# Altium schematic sheet Display and Control.SchDoc("Display and Control")
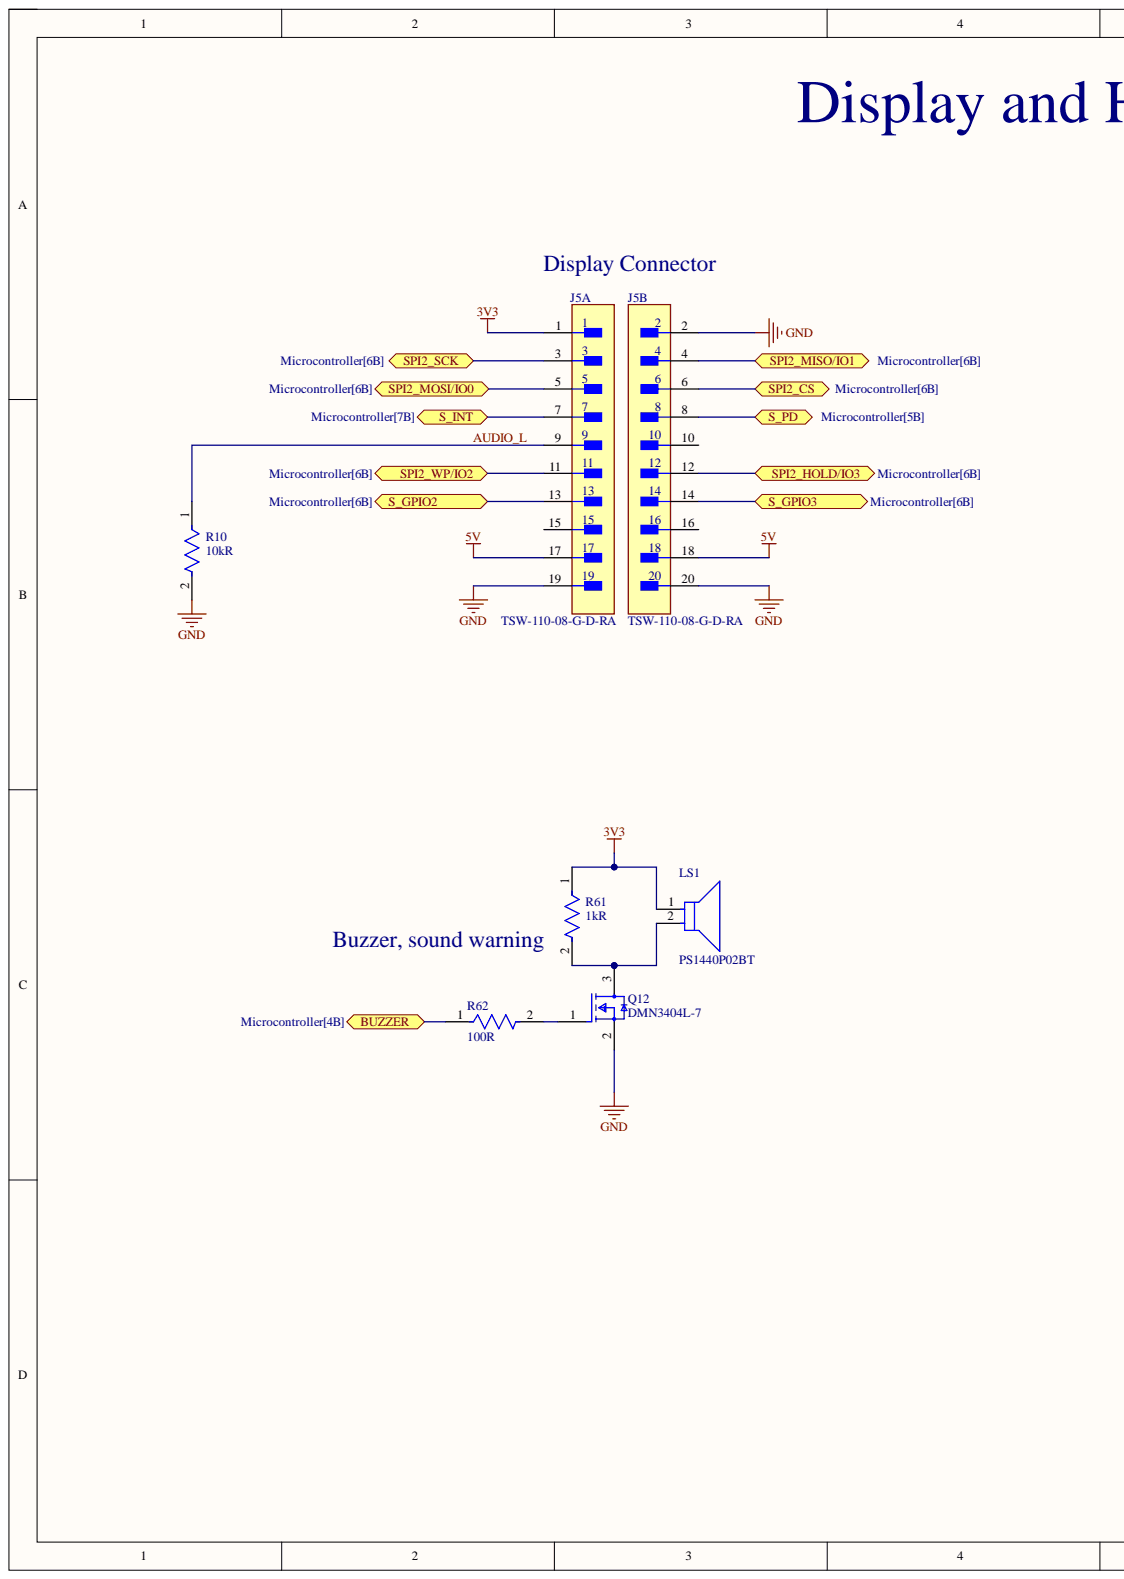
[diagram p0: Display and Control.SchDoc, left]
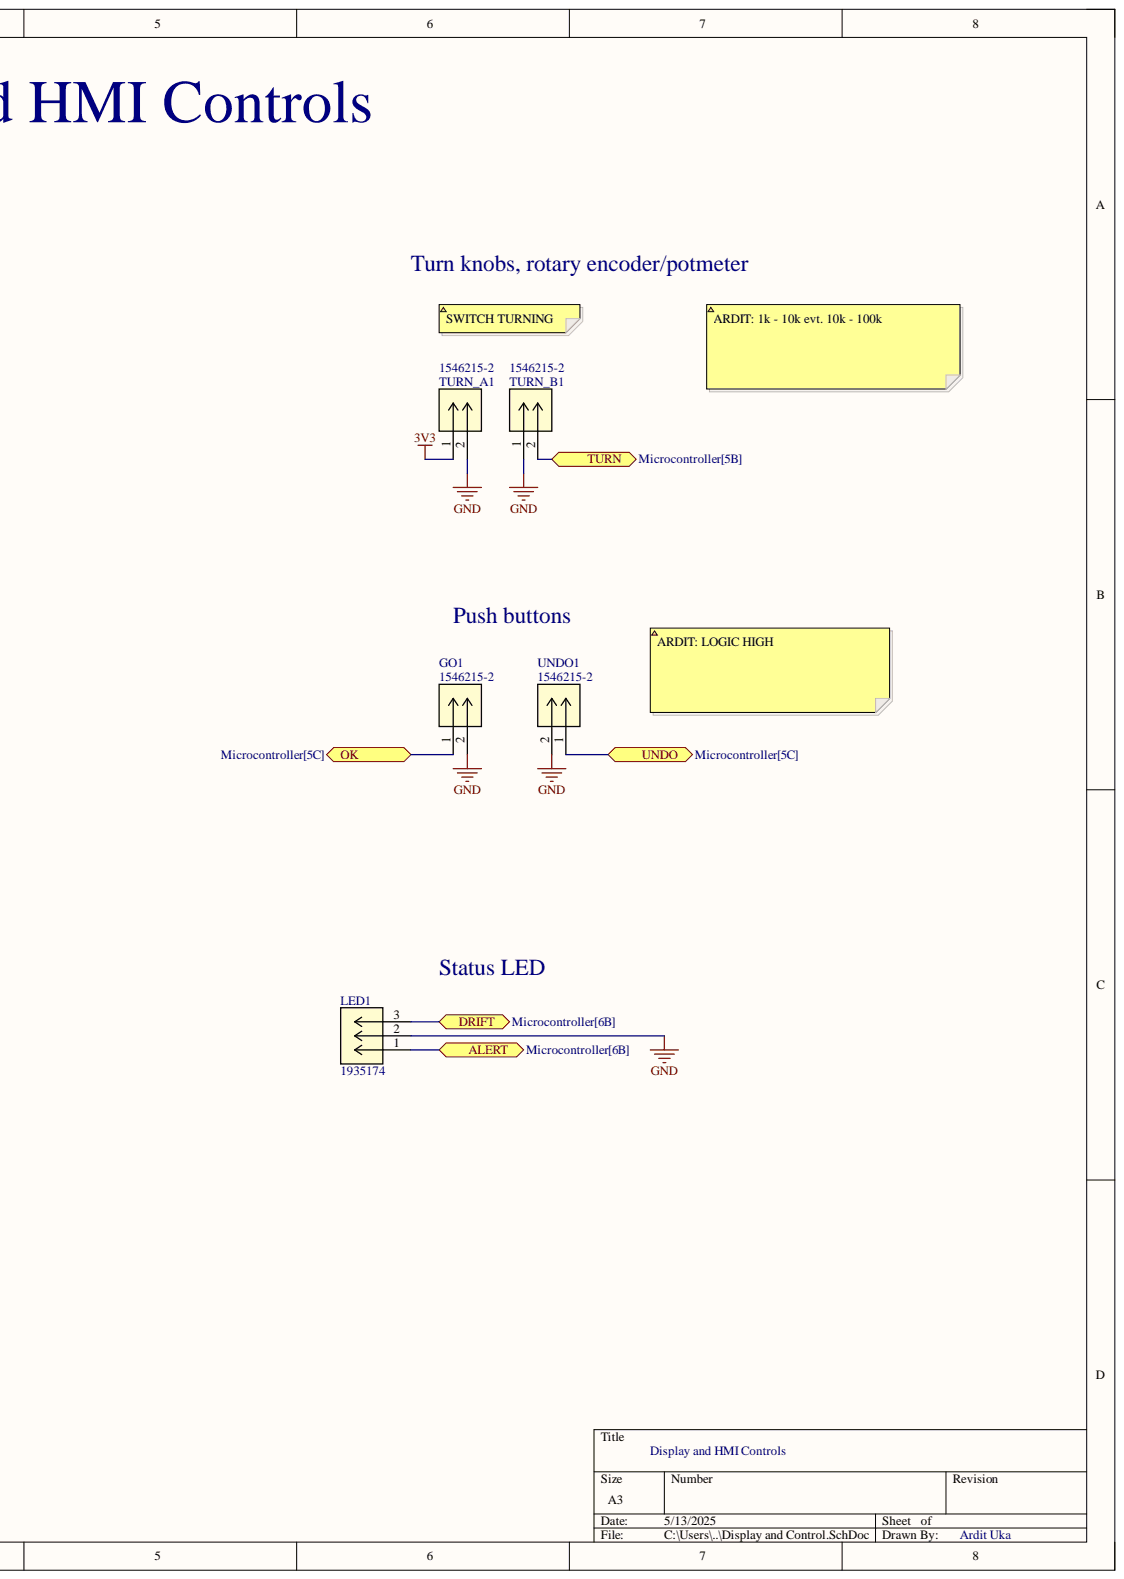
[diagram p1: Display and Control.SchDoc, right]

## Parts
GO1  1546215-2  [CONN_1546215-2_TEC]
J5  TSW-110-08-G-D-RA  [FP-TSW-110-08-G-D-RA-MFG]  (parts A,B)
LED1  1935174  [CONN_1935174_PXC]
LS1  PS1440P02BT  [FP-PS1440P02BT-MFG]
Q12  DMN3404L-7  [FP-SOT23-MFG]
R10  Resistance: 10kR  [FP-MCT0603-IPC_C]  (hidden comment: MCT06030C1002FP500)
R61  1KR  [FP-AC0603-IPC_B]
R62  100R  [FP-ERA3A-IPC_B]
TURN_A1  1546215-2  [CONN_1546215-2_TEC]
TURN_B1  1546215-2  [CONN_1546215-2_TEC]
UNDO1  1546215-2  [CONN_1546215-2_TEC]

## Ports
ALERT, BUZZER, DRIFT, OK, S_GPIO2, S_GPIO3, S_INT, S_PD, SPI2_CS, SPI2_HOLD/IO3, SPI2_MISO/IO1, SPI2_MOSI/IO0, SPI2_SCK, SPI2_WP/IO2, TURN, UNDO

## Nets
3V3: J5.1 LS1.1 R61.1 TURN_A1.1
5V: J5.17 J5.18
GND: GO1.2 J5.2 J5.19 J5.20 LED1.2 Q12.2 R10.2 TURN_A1.2 TURN_B1.1 UNDO1.2
ALERT: LED1.1 ; port ALERT
AUDIO_L: J5.9 R10.1
BUZZER: R62.1 ; port BUZZER
DRIFT: LED1.3 ; port DRIFT
OK: GO1.1 ; port OK
S_GPIO2: J5.13 ; port S_GPIO2
S_GPIO3: J5.14 ; port S_GPIO3
S_INT: J5.7 ; port S_INT
S_PD: J5.8 ; port S_PD
SPI2_CS: J5.6 ; port SPI2_CS
SPI2_HOLD/IO3: J5.12 ; port SPI2_HOLD/IO3
SPI2_MISO/IO1: J5.4 ; port SPI2_MISO/IO1
SPI2_MOSI/IO0: J5.5 ; port SPI2_MOSI/IO0
SPI2_SCK: J5.3 ; port SPI2_SCK
SPI2_WP/IO2: J5.11 ; port SPI2_WP/IO2
TURN: TURN_B1.2 ; port TURN
UNDO: UNDO1.1 ; port UNDO
NetLS1_2: LS1.2 Q12.3 R61.2
NetQ12_1: Q12.1 R62.2

Not connected: J5 10 15 16

## Library data of the parts
GO1 TURN_A1 TURN_B1 UNDO1 = 1546215-2: No Description Available; Mfr_Name: TE Connectivity; Component Kind: Standard; Library Name: 1546215-2.IntLib; RefDes: RefDes; Type: Type
J5 = CMP-02766-000122-1: CONN HEADER R/A 20POS 2.54MM; Connector Type: Male Pin; Gender: Male; Max Current Rating: 7.6A; Max Voltage Rating (AC): 450V; Number of Contacts: 20; Row Spacing: 0.1inch; Color: Black; Component Kind: Standard; Contact Material: Bronze; Contact Mating Length: 0.23inch; Contact Plating: Gold; Depth: 12.44mm; Housing Color: Black; Housing Material: Polyester; Lead Free: Lead Free; Length: 25.4mm; Library Name: Altium Content Vault; Max Operating Temperature: 125°C; Min Operating Temperature: -55°C; Mount: Through Hole; Number of Positions: 20; Number of Rows: 2; Orientation: Right Angle; Packaging: Bulk; Pitch: 2.54mm; Radiation Hardening: No; REACH SVHC: No SVHC; Termination: Solder; Width: 2.54mm
LED1 = 1935174: No Description Available; Mfr_Name: Phoenix Contact; Component Kind: Standard; Library Name: 1935174.IntLib; RefDes: RefDes; Type: Type
LS1 = CMP-08413-000003-1: AUDIO PIEZO TRANSDUCER 30V TH; Frequency: 4kHz; Number of Terminals/Pins: 2; Operating Supply Voltage: 3V; Washable: No; Component Kind: Standard; Diameter: 14mm; Height: 8mm; Lead Free: Lead Free; Library Name: Altium Content Vault; Max Operating Temperature: 70°C; Min Operating Temperature: -10°C; Mount: Through Hole; Package Quantity: 350; Packaging: Tape and Reel; RoHS Compliant: Yes; Self Resonant Frequency: 4kHz; Termination: PC Pins; Voltage Rating (DC): 3V
Q12 = CMP-12801-000054-1: MOSFET N-CH 30V 5.8A SOT-23; Manufacturer: Diodes Inc.; Automotive Grade: NA; Continuous Drain Current: 4.2A; Depletion Mode: False; Drain To Source Resistance: 24mΩ; Gate Charge At Vgs: 11.3nC @ 10V; Gate To Source Voltage: 20V; Input Capacitace At Vds: 498pF @ 15V; Max Forward Diode Voltage: 1V; Max Junction Temp: +150°C; Number Of Channels: 1; Power Dissipation: 0.72W; Pulse Drain Current: 30A; Rthja Max: 173°C/W; Standoff Height: 0.013mm; Threshold Vgs Max: 2V; Turn Off Delay Time: 13.92ns; Turn On Delay Time: 3.41ns; Typ Forward Diode Voltage: 0.75V; Automotive: No; Category: Trans; Component Kind: Standard; ComponentLink2Description: Datasheet; ComponentLink2URL: https://www.diodes.com/assets/Datasheets/ds31787.pdf; Device Class L1: Discrete Semiconductors; Device Class L2: Transistors; Device Class L3: MOSFETs; Digikey Description: MOSFET N-CH 30V 5.8A SOT-23; Drain To Source Breakdown Voltage: 30V; Drain To Source Voltage: 30V; Footprint Url: https://www.diodes.com/assets/Package-Files/SOT23.pdf; Height: 1.1mm; Lead Free: Yes; Library Name: Altium Content Vault; Manufacturer Part Number: DMN3404L-7; Mouser Description: N-Channel 30 V 28 mOhm Surface Mount Enhancement Mode Mosfet - SOT-23-3; Number Of N Channels: 1; Octopart: https://octopart.com/dmn3404l-7-diodes+inc.-12409285; Package: SOT23; RoHS: TRUE; Temperature: -55°C to +150°C; Temperature Range High: +150°C; Temperature Range Low: -55°C; Threshold Vgs Min: 1V
R10 = CMP-02407-000418-1: RES SMD 100 OHM 1% 1/8W 0603; Max Power Dissipation: 125mW; Power Rating: 100mW; Temperature Coefficient: 50ppm/°C; Voltage Rating: 75V; Case Code (Imperial): 0603; Case Code (Metric): 1608; Case/Package: 0603; Component Kind: Standard; Composition: Thin Film; Contact Plating: Tin; Depth: 0.85mm; Height: 0.022inch; Lead Free: Lead Free; Length: 1.55mm; Library Name: Altium Content Vault; Max Operating Temperature: 125°C; Military Standard: Not; Min Operating Temperature: -55°C; Mount: Surface Mount; Number of Terminations: 2; Package Quantity: 1; Packaging: Tape and Reel; Radiation Hardening: No; Tolerance: 1%; Voltage Rating (DC): 75V; Width: 0.8mm
R61 = CMP-2000-04828-3: RES 1kΩ 1.00% 0.1W 0603; Max Power Dissipation: 100mW; Temperature Coefficient: 100ppm/°C; Tolerance: 1%; Voltage Rating: 50V; Case Code (Imperial): 0603; Case Code (Metric): 1608; Case/Package: 0603; Component Kind: Standard; Construction: Rectangular; Depth: 0.8mm; Features: Moisture Resistant; Height: 0.022inch; Lead Free: Lead Free; Length: 0.063inch; Library Name: Altium Content Vault; Max Operating Temperature: 155°C; Military Standard: Not; Min Operating Temperature: -55°C; Number of Terminations: 2; Package Quantity: 1; Packaging: Tape and Reel; Power Rating: 100mW; Ratings: AEC-Q200; Resistance: 1kR; Resistor Type: Thick Film; RoHS Compliant: Yes; Series: AC; Size Code: 0603; Termination: SMD/SMT; Width: 0.031inch
R62 = CMP-2000-00870-2: RES SMD 100 OHM 0.1% 1/10W 0603; Max Power Dissipation: 100mW; Temperature Coefficient: 25ppm/°C; Voltage Rating: 75V; Case Code (Imperial): 0603; Case Code (Metric): 1608; Case/Package: 0603; Component Kind: Standard; Construction: Rectangular; Contact Plating: Tin; Depth: 0.8mm; Height: 0.45mm; Length: 1.6mm; Library Name: Altium Content Vault; Max Operating Temperature: 155°C; Military Standard: Not; Min Operating Temperature: -55°C; Mount: Surface Mount; Number of Pins: 2; Number of Terminations: 2; Package Quantity: 5000; Packaging: Tape and Reel; Power Rating: 100mW; Radiation Hardening: No; REACH SVHC: No SVHC; Resistance: 100R; Resistance Tolerance: 0.1%; Resistor Type: Thin Film; RoHS Compliant: Yes; Series: ERA-3A; Size Code: 0603; Technology: METAL FILM; Termination: SMD/SMT; Tolerance: 0.1%; Width: 0.8mm
